# Altium schematic sheet LCD.SchDoc
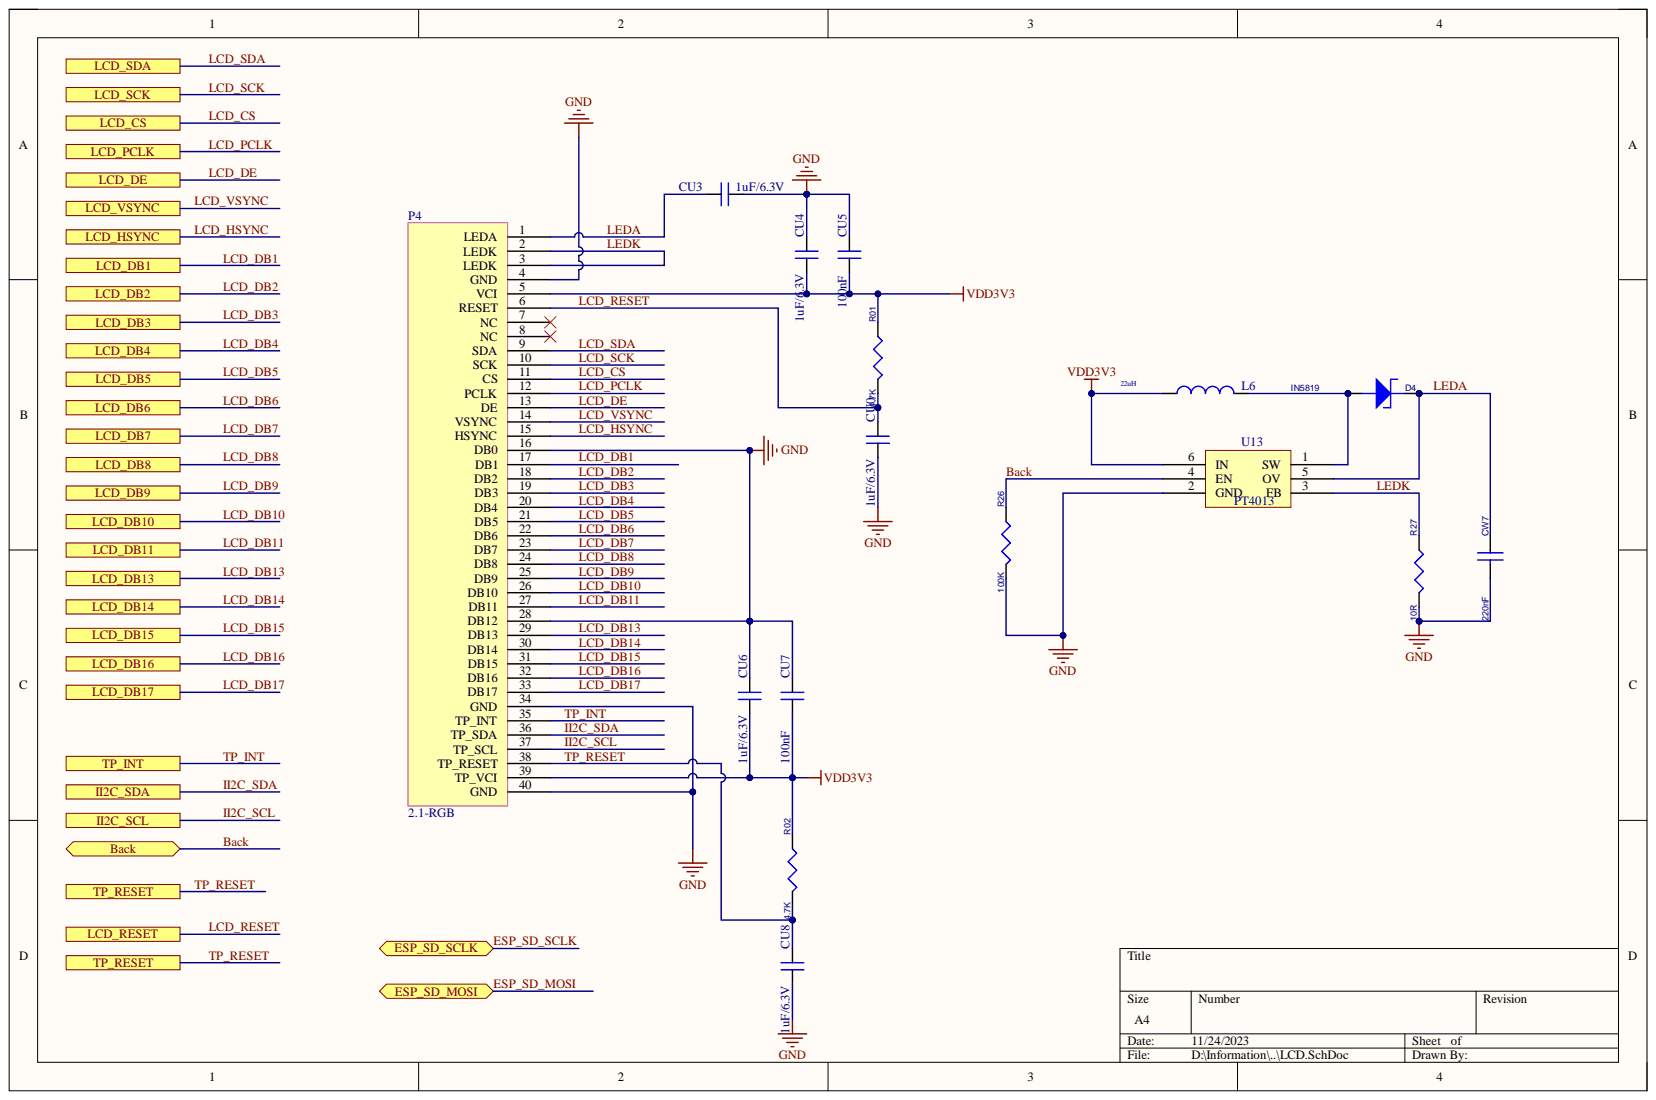
[diagram p0: LCD.SchDoc]

## Parts
CU0  1uF/6.3V  [LC-0402_C]
CU3  1uF/6.3V  [LC-0402_C]
CU4  1uF/6.3V  [LC-0402_C]
CU5  100nF  [LC-0402_C]
CU6  1uF/6.3V  [LC-0402_C]
CU7  100nF  [LC-0402_C]
CU8  1uF/6.3V  [LC-0402_C]
CW7  220nF  [LC-1206]
D4  IN5819  [SDO123]
L6  22uH  [IND-SMD_L2.5-W2.0]
P4  2.1-RGB  [FPC-SMD_40P-P0.50_HDGC__0.5K-DX-40PWB]
R01  4.7K  [LC-0402_R]
R02  4.7K  [LC-0402_R]
R26  100K  [LC-0402_R]
R27  10R  [LC-0402_R]
U13  PT4013  [SOT-23-6_L2.9-W1.6-P0.95-LS2.8-BR]

## Ports
Back, ESP_SD_MOSI, ESP_SD_SCLK, II2C_SCL, II2C_SDA, LCD_CS, LCD_DB1, LCD_DB2, LCD_DB3, LCD_DB4, LCD_DB5, LCD_DB6, LCD_DB7, LCD_DB8, LCD_DB9, LCD_DB10, LCD_DB11, LCD_DB13, LCD_DB14, LCD_DB15, LCD_DB16, LCD_DB17, LCD_DE, LCD_HSYNC, LCD_PCLK, LCD_RESET, LCD_SCK, LCD_SDA, LCD_VSYNC, TP_INT, TP_RESET

## Nets
GND: CU0.2 CU3.2 CU4.1 CU5.1 CU6.1 CU7.1 CU8.2 CW7.2 P4.4(GND) P4.16(DB0) P4.28(DB12) P4.34(GND) P4.40(GND) R26.2 R27.2 U13.2(GND)
VDD3V3: CU4.2 CU5.2 CU6.2 CU7.2 L6.1 P4.5(VCI) P4.39(TP_VCI) R01.1 R02.1 U13.6(IN)
Back: R26.1 U13.4(EN) ; port Back
II2C_SCL: P4.37(TP_SCL) ; port II2C_SCL
II2C_SDA: P4.36(TP_SDA) ; port II2C_SDA
LCD_CS: P4.11(CS) ; port LCD_CS
LCD_DB1: P4.17(DB1) ; port LCD_DB1
LCD_DB2: P4.18(DB2) ; port LCD_DB2
LCD_DB3: P4.19(DB3) ; port LCD_DB3
LCD_DB4: P4.20(DB4) ; port LCD_DB4
LCD_DB5: P4.21(DB5) ; port LCD_DB5
LCD_DB6: P4.22(DB6) ; port LCD_DB6
LCD_DB7: P4.23(DB7) ; port LCD_DB7
LCD_DB8: P4.24(DB8) ; port LCD_DB8
LCD_DB9: P4.25(DB9) ; port LCD_DB9
LCD_DB10: P4.26(DB10) ; port LCD_DB10
LCD_DB11: P4.27(DB11) ; port LCD_DB11
LCD_DB13: P4.29(DB13) ; port LCD_DB13
LCD_DB14: P4.30(DB14) ; port LCD_DB14
LCD_DB15: P4.31(DB15) ; port LCD_DB15
LCD_DB16: P4.32(DB16) ; port LCD_DB16
LCD_DB17: P4.33(DB17) ; port LCD_DB17
LCD_DE: P4.13(DE) ; port LCD_DE
LCD_HSYNC: P4.15(HSYNC) ; port LCD_HSYNC
LCD_PCLK: P4.12(PCLK) ; port LCD_PCLK
LCD_RESET: CU0.1 P4.6(RESET) R01.2 ; port LCD_RESET
LCD_SCK: P4.10(SCK) ; port LCD_SCK
LCD_SDA: P4.9(SDA) ; port LCD_SDA
LCD_VSYNC: P4.14(VSYNC) ; port LCD_VSYNC
LEDA: CU3.1 CW7.1 D4.2 P4.1(LEDA) U13.5(OV)
LEDK: P4.2(LEDK) P4.3(LEDK) R27.1 U13.3(FB)
TP_INT: P4.35(TP_INT) ; port TP_INT
TP_RESET: CU8.1 P4.38(TP_RESET) R02.2 ; port TP_RESET
NetD4_1: D4.1 L6.2 U13.1(SW)
ESP_SD_MOSI: ; port ESP_SD_MOSI
ESP_SD_SCLK: ; port ESP_SD_SCLK

Not connected: P4 7(NC) 8(NC)

## Library data of the parts
CU0 CU3-CU8 = C: Component Kind: Standard; Library Name: 光学蓝牙.SCHLIB
CW7 = CAP NP: Component Kind: Standard
D4 = DIODE ZENER_4_1: room: power1; Component Kind: Standard; Library Name: 光学蓝牙.SCHLIB
L6 = (no symbol name): Power Inductor, 4.7uH, 0.7A, 260mR DCR; Manufacturer: Taiyo Yuden; Manufacturer Part Number: CBC2518T4R7M; Part Creator: JG; XMOS Part Number: E-09-0011; Approved: NO; Approx Volume Cost ($): 0.07; DEVICE: L; FORWARD_PCB: 1; LEVEL: STD; Max DC Resistance: 0.26R; Rated Current (Saturation): 0.68A; Rated Current (Temp Rise): 0.92A; Tolerance (%): 20; VALUE: 2.0nH; Component Kind: Standard; Datasheet: http://www.yuden.co.jp/us/product/pdf/ecb2_e.pdf; Digikey Link: http://search.digikey.com/scripts/DkSearch/dksus.dll?lang=en&site=US&keywords=CBC2518T4R7M; Library Name: MySchlib.SchLib; Max Height (mm): 2
R01 R02 R26 R27 = R: Component Kind: Standard; Library Name: 光学蓝牙.SCHLIB
P4 = 2.1-RGB: Component Kind: Standard; Library Name: 885阿里云PCB_Project1.SCHLIB
U13 = PT4013: Component Kind: Standard; Library Name: T_RGB.SCHLIB
